# Altium schematic sheet roll_motorl_control.SchDoc(roll_motorl_control)
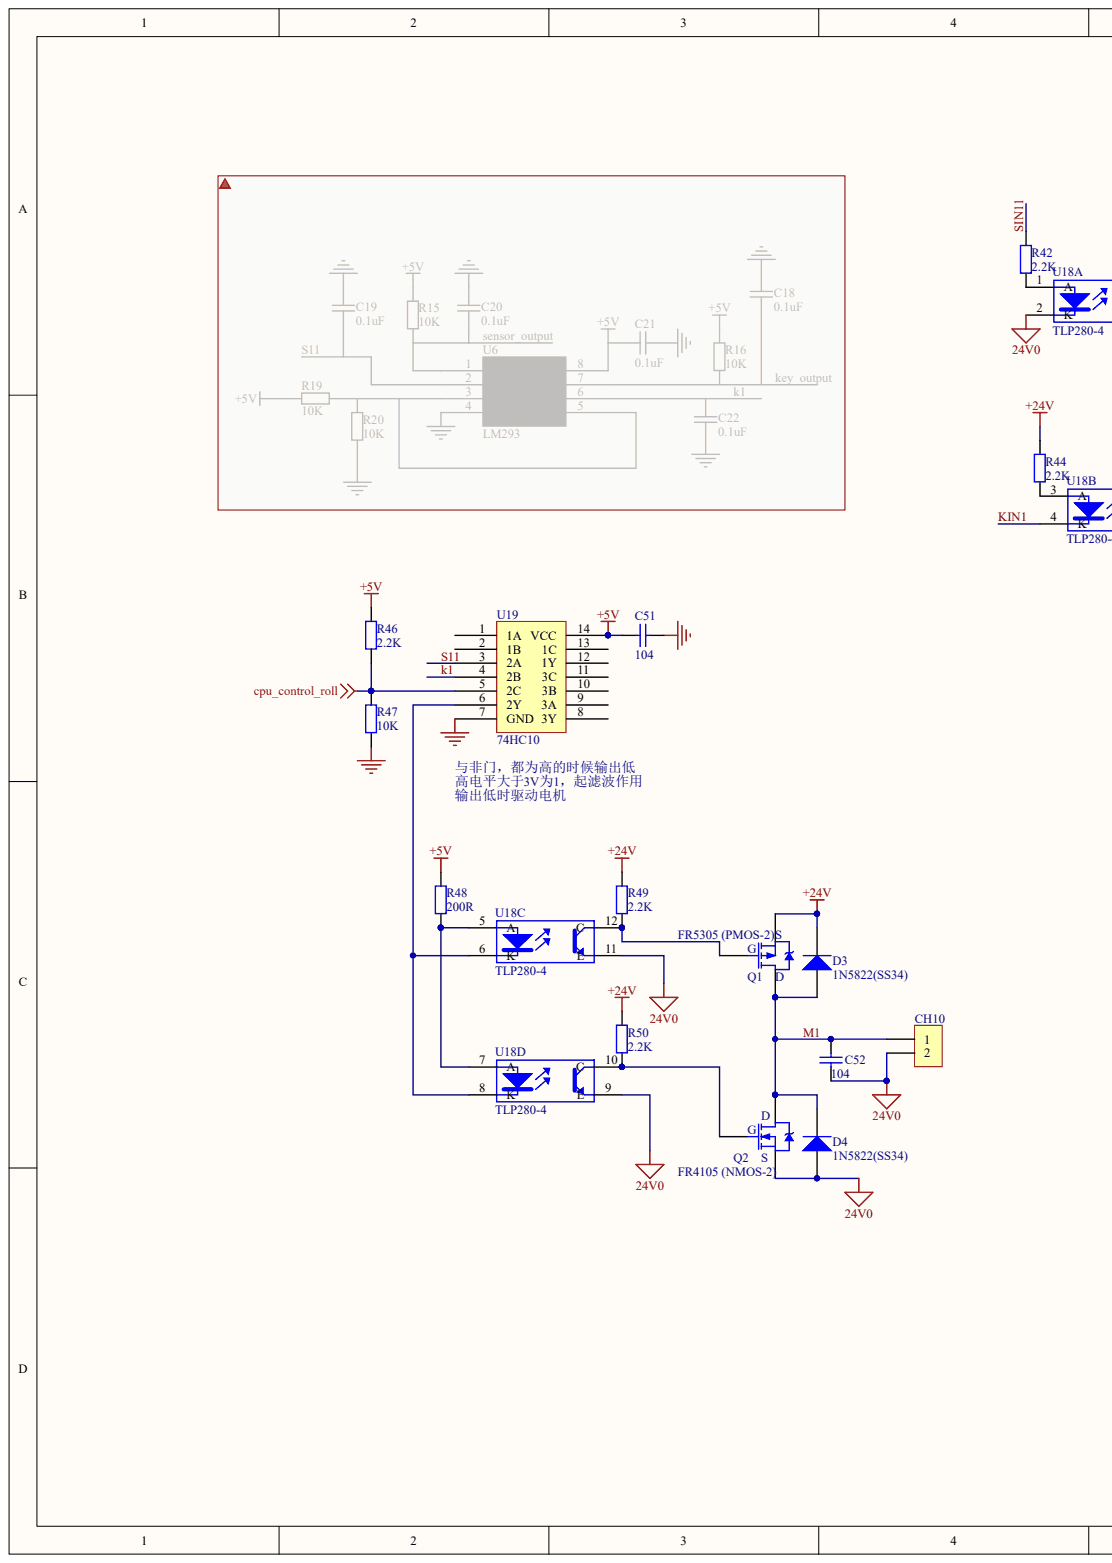
[diagram p0: roll_motorl_control.SchDoc, left]
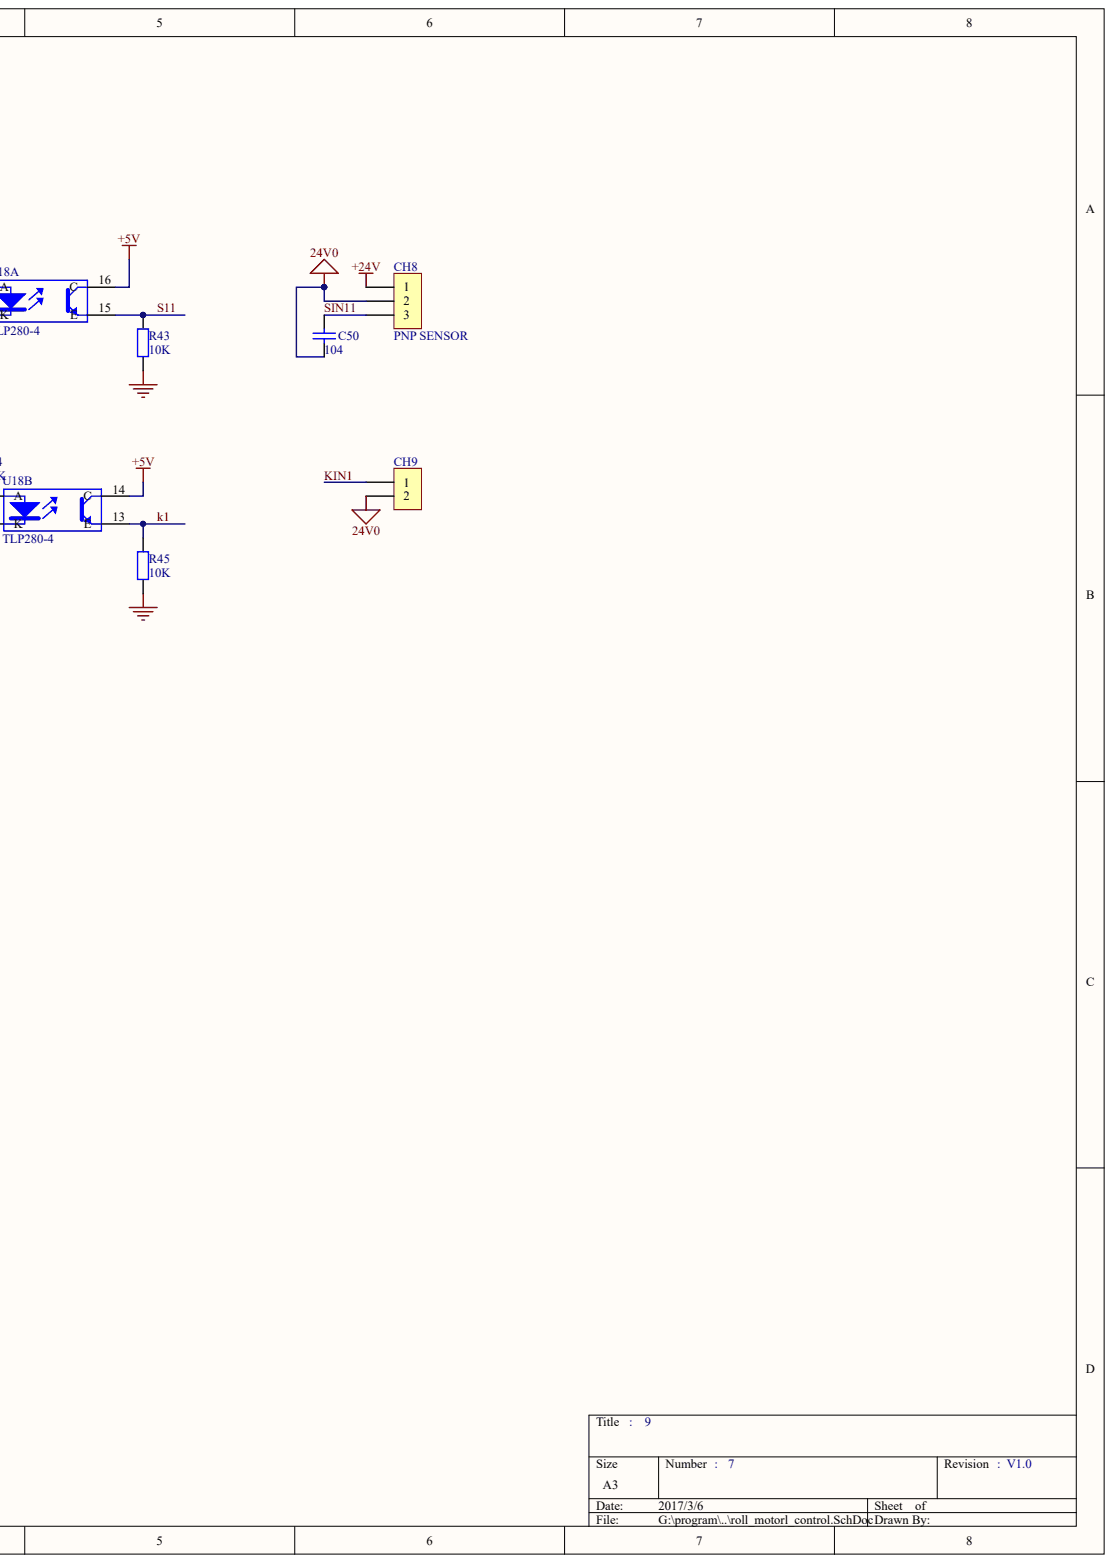
[diagram p1: roll_motorl_control.SchDoc, right]

## Parts
C50  value 104  (hidden comment: Cap)
C51  value 104  (hidden comment: Cap)
C52  value 104  (hidden comment: Cap)
CH8  HEADER1X3  (value not drawn)
CH9  HEADER1X2  (value not drawn)
CH10  HEADER1X2  (value not drawn)
D3  1N5822(SS34)
D4  1N5822(SS34)
Q1  FR5305 (PMOS-2)
Q2  FR4105 (NMOS-2)
R42  value 2.2K  (hidden comment: Res2)
R43  value 10K  (hidden comment: Res2)
R44  value 2.2K  (hidden comment: Res2)
R45  value 10K  (hidden comment: Res2)
R46  value 2.2K  (hidden comment: Res2)
R47  value 10K  (hidden comment: Res2)
R48  value 200R  (hidden comment: Res2)
R49  value 2.2K  (hidden comment: Res2)
R50  value 2.2K  (hidden comment: Res2)
U18  TLP280-4  (parts A,B,C,D)
U19  74HC10

## Nets
24V0: C50.1 C52.1 CH8.2 CH9.2 CH10.2 D4.1 Q2.3 U18.2(K) U18.9(E) U18.11(E)
+5V: C51.2 R46.1 R48.1 U18.14(C) U18.16(C) U19.14(VCC)
+24V: CH8.1 D3.2 Q1.3 R44.1 R49.1 R50.1
CPU_CONTROL_ROLL: R46.2 R47.1 U19.5(2C)
GND: C51.1 R43.2 R45.2 R47.2 U19.7(GND)
K1: R45.1 U18.13(E) U19.4(2B)
KIN1: CH9.1 U18.4(K)
M1: C52.2 CH10.1 D3.1 D4.2 Q1.2 Q2.2
S11: R43.1 U18.15(E) U19.3(2A)
SIN11: C50.2 CH8.3 R42.1
NetQ1_1: Q1.1 R49.2 U18.12(C)
NetQ2_1: Q2.1 R50.2 U18.10(C)
NetR42_2: R42.2 U18.1(A)
NetR44_2: R44.2 U18.3(A)
NetR48_2: R48.2 U18.5(A) U18.7(A)
NetU18_6: U18.6(K) U18.8(K) U19.6(2Y)

Not connected: U19 1(1A) 2(1B) 8(3Y) 9(3A) 10(3B) 11(3C) 12(1Y) 13(1C)

## Library data of the parts
Q1 = (no symbol name): Note: Pin 4 not drawn and electrically connected to Pin 2.; Published: 8-Jun-2000; LatestRevisionDate: 17-Jul-2002; LatestRevisionNote: Re-released for DXP Platform.; DatasheetDocument: PD-9.390D; PackageReference: TO-220AB; Code_JEDEC: TO-220-AB; ComponentLink1Description: Manufacturer Link; ComponentLink1URL: http://www.irf.com/; Publisher: Altium Limited
Q2 = (no symbol name): Note: Pin 2 is electrically connected to pin 4.; Published: 8-Jun-2000; LatestRevisionDate: 17-Jul-2002; LatestRevisionNote: Re-released for DXP Platform.; DatasheetDocument: PD-9.814; PackageReference: TO-220AB; Code_JEDEC: TO-220-AB; ComponentLink1Description: Manufacturer Link; ComponentLink1URL: http://www.irf.com/; Publisher: Altium Limited
C50-C52 CH8-CH10 D3 D4 R42-R50 U18 U19 = (no symbol name)
  C50-C52: Published: 8-Jun-2000; LatestRevisionDate: 17-Jul-2002; LatestRevisionNote: Re-released for DXP Platform.; PackageReference: RAD-0.3
  CH8: : PNP SENSOR
  D3 D4: Published: 8-Jun-2000; LatestRevisionDate: 17-Jul-2002; LatestRevisionNote: Re-released for DXP Platform.; DatasheetDocument: 1997; PackageReference: DO-35; Code_JEDEC: DO-204-AH; ComponentLink1Description: Manufacturer Link; ComponentLink1URL: http://www.fairchildsemi.com/; ComponentLink2Description: Datasheet; ComponentLink2URL: http://www.fairchildsemi.com/ds/1N%2F1N4148.pdf
  R42-R50: Published: 8-Jun-2000; LatestRevisionDate: 17-Jul-2002; LatestRevisionNote: Re-released for DXP Platform.; PackageReference: AXIAL-0.4
  C50-C52 D3 D4 R42-R50: Publisher: Altium Limited
